# Altium schematic sheet main.SchDoc(main)
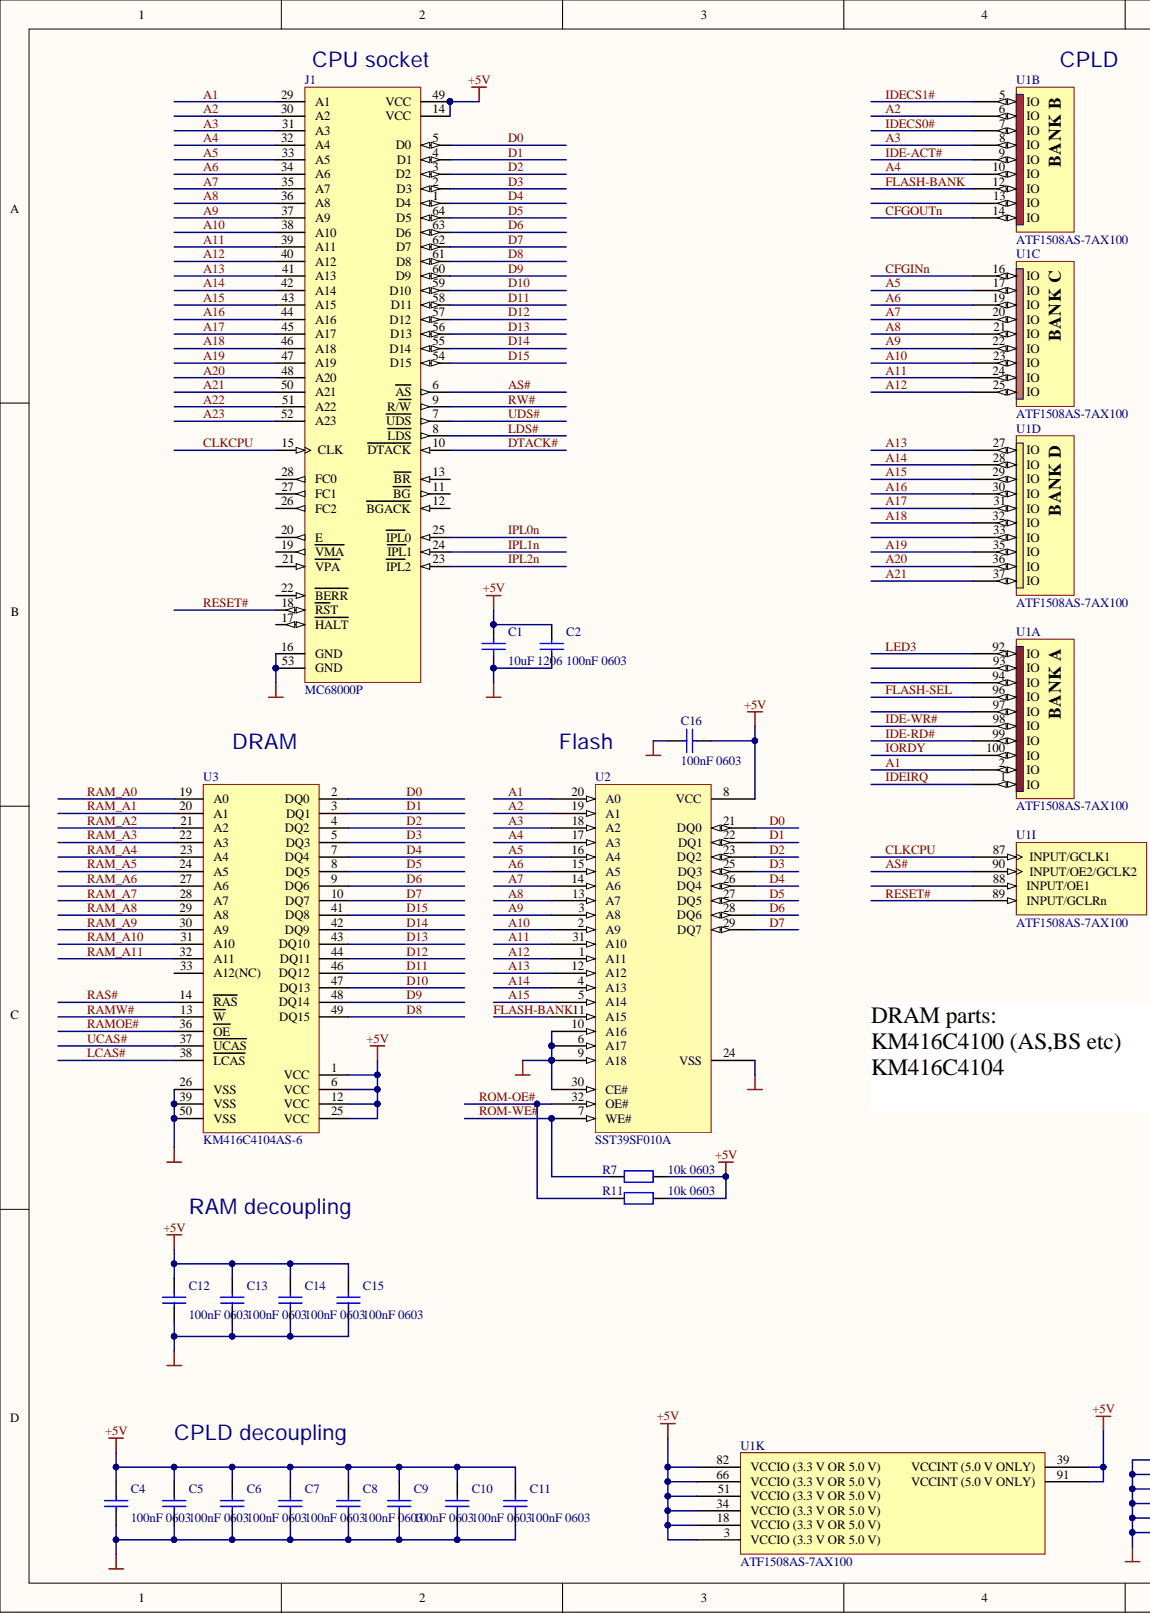
[diagram p0: main.SchDoc, left]
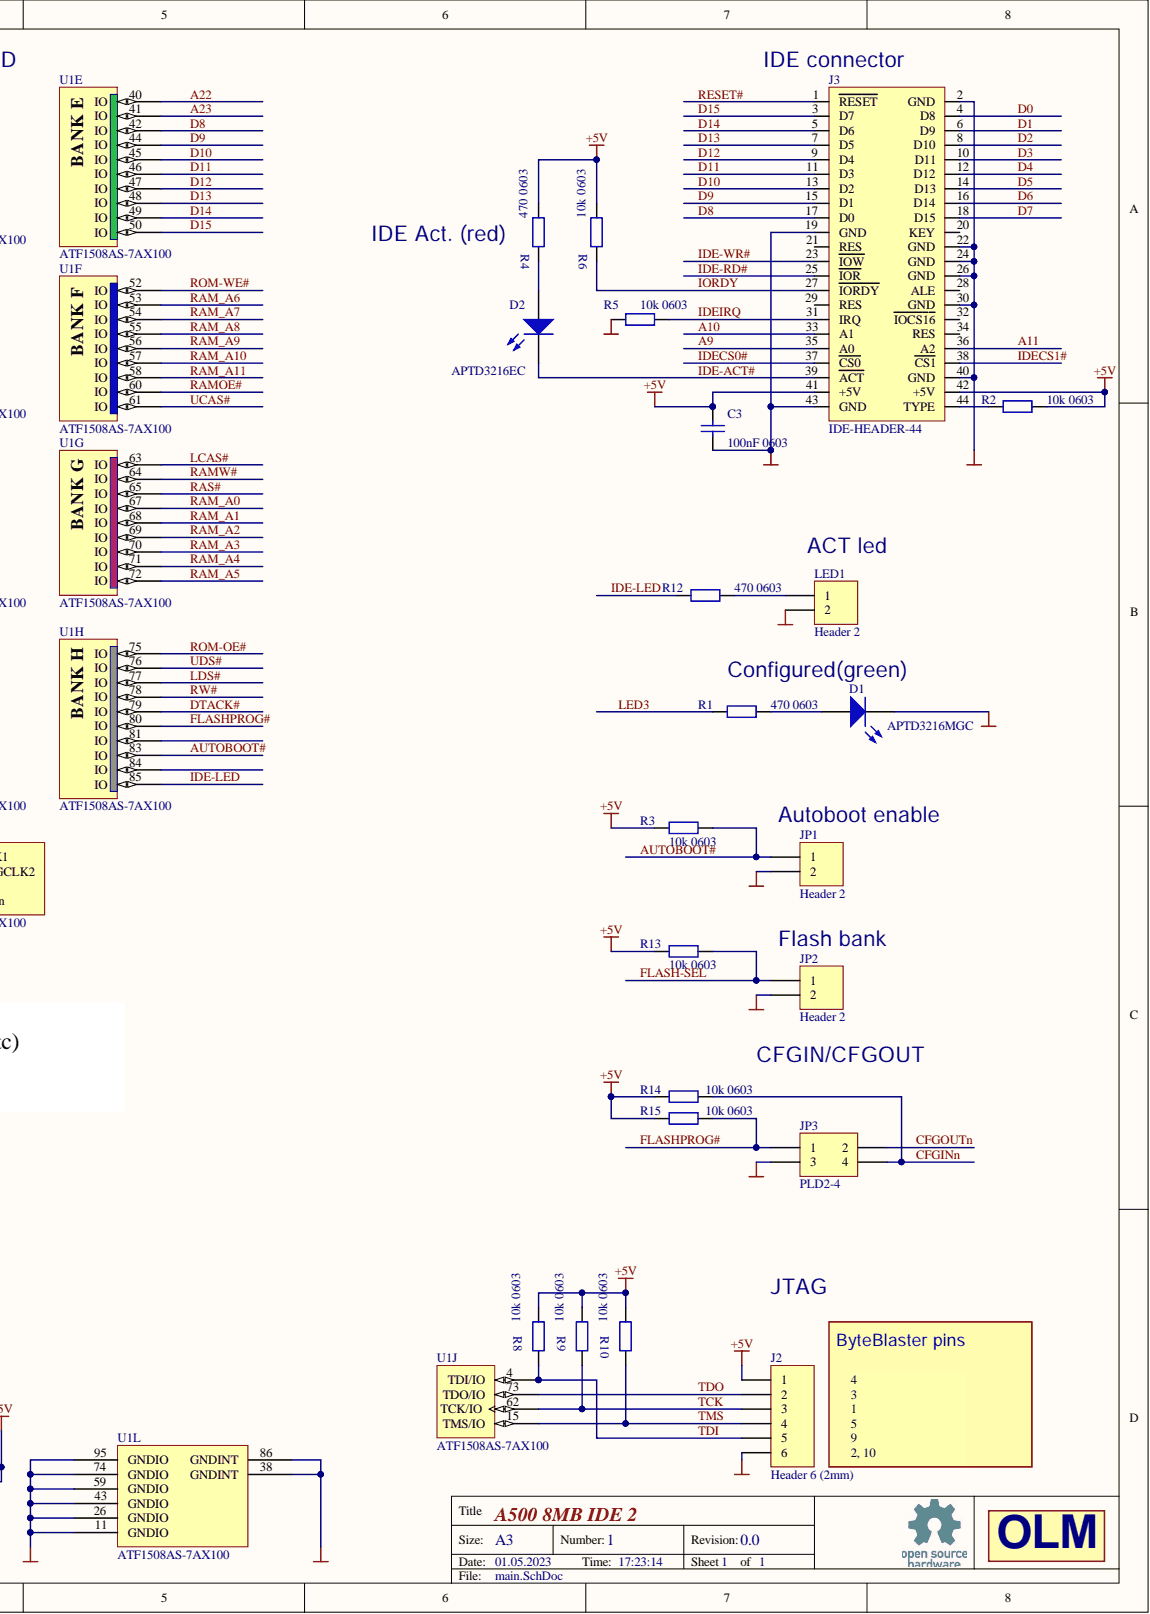
[diagram p1: main.SchDoc, right]

## Parts
A1  OSHW  [OSHW-LOGO-10MM]  (value not drawn)
C1  value 10uF 1206  [SM/C_1206]  (hidden comment: Capacitor)
C2  value 100nF 0603  [SM/C_0603]  (hidden comment: Capacitor)
C3  value 100nF 0603  [SM/C_0603]  (hidden comment: Cap)
C4  Cap  [SM/C_0603]  (value not drawn)
C5  value 100nF 0603  [SM/C_0603]  (hidden comment: Cap)
C6  value 100nF 0603  [SM/C_0603]  (hidden comment: Cap)
C7  value 100nF 0603  [SM/C_0603]  (hidden comment: Cap)
C8  value 100nF 0603  [SM/C_0603]  (hidden comment: Cap)
C9  value 100nF 0603  [SM/C_0603]  (hidden comment: Cap)
C10  value 100nF 0603  [SM/C_0603]  (hidden comment: Cap)
C11  value 100nF 0603  [SM/C_0603]  (hidden comment: Cap)
C12  Cap  [SM/C_0603]  (value not drawn)
C13  value 100nF 0603  [SM/C_0603]  (hidden comment: Cap)
C14  value 100nF 0603  [SM/C_0603]  (hidden comment: Cap)
C15  value 100nF 0603  [SM/C_0603]  (hidden comment: Cap)
C16  value 100nF 0603  [SM/C_0603]  (hidden comment: Cap)
D1  value APTD3216MGC  [SM/LED_0805_21_BLUE]  (hidden comment: LED)
D2  value APTD3216EC  [SM/LED_0805_21_BLUE]  (hidden comment: LED)
J1  MC68000P  [DIP.100/64/W.900-SKT]
J2  Header 6 (2mm)  [PLS2-6-RA-SMD]
J3  IDE-HEADER-44  [PLD2-44]
JP1  Header 2  [PLS-2-2MM]
JP2  Header 2  [PLS-2-2MM]
JP3  value PLD2-4  [PLD2-4]  (hidden comment: Header 2X2)
LED1  Header 2  [PLS-2-2MM]
R1  value 470 0603  [SM/R_0603]  (hidden comment: Резистор)
R2  value 10k 0603  [SM/R_0603]  (hidden comment: Резистор)
R3  value 10k 0603  [SM/R_0603]  (hidden comment: Resistor)
R4  value 470 0603  [SM/R_0603]  (hidden comment: Resistor)
R5  value 10k 0603  [SM/R_0603]  (hidden comment: Resistor)
R6  value 10k 0603  [SM/R_0603]  (hidden comment: Resistor)
R7  value 10k 0603  [SM/R_0603]  (hidden comment: Резистор)
R8  value 10k 0603  [SM/R_0603]  (hidden comment: Resistor)
R9  value 10k 0603  [SM/R_0603]  (hidden comment: Resistor)
R10  value 10k 0603  [SM/R_0603]  (hidden comment: Resistor)
R11  value 10k 0603  [SM/R_0603]  (hidden comment: Резистор)
R12  value 470 0603  [SM/R_0603]  (hidden comment: Резистор)
R13  value 10k 0603  [SM/R_0603]  (hidden comment: Resistor)
R14  value 10k 0603  [SM/R_0603]  (hidden comment: Resistor)
R15  value 10k 0603  [SM/R_0603]  (hidden comment: Resistor)
U1  ATF1508AS-7AX100  [TQFP100_16mm]  (parts A,B,C,D,E,F,G,H,I,J,K,L)
U2  value SST39SF010A  [TSOP32_WH_SST_MCH]  (hidden comment: SST39SF040A)
U3  value KM416C4104AS-6  [TSOP-II-50]  (hidden comment: 4Mx16 DRAM TSOP50)

## Nets
+5V: C1.2 C2.2 C3.2 C4.2 C5.2 C6.2 C7.2 C8.2 C9.2 C10.2 C11.2 C12.2 C13.2 C14.2 C15.2 C16.1 J1.14(VCC) J1.49(VCC) J2.1 J3.41(+5V) J3.42(+5V) R2.2 R3.2 R4.2 R6.2 R7.2 R8.2 R9.2 R10.2 R11.2 R13.2 R14.2 R15.2 U1.3(VCCIO_(3.3_V_OR_5.0_V)) U1.18(VCCIO_(3.3_V_OR_5.0_V)) U1.34(VCCIO_(3.3_V_OR_5.0_V)) U1.39(VCCINT_(5.0_V_ONLY)) U1.51(VCCIO_(3.3_V_OR_5.0_V)) U1.66(VCCIO_(3.3_V_OR_5.0_V)) U1.82(VCCIO_(3.3_V_OR_5.0_V)) U1.91(VCCINT_(5.0_V_ONLY)) U2.8(VCC) U3.1(VCC) U3.6(VCC) U3.12(VCC) U3.25(VCC)
GND: C1.1 C2.1 C3.1 C4.1 C5.1 C6.1 C7.1 C8.1 C9.1 C10.1 C11.1 C12.1 C13.1 C14.1 C15.1 C16.2 D1.2 J1.16(GND) J1.53(GND) J2.6 J3.2(GND) J3.19(GND) J3.22(GND) J3.24(GND) J3.26(GND) J3.30(GND) J3.40(GND) J3.43(GND) JP1.2 JP2.2 JP3.3 LED1.2 R5.1 U1.11(GNDIO) U1.26(GNDIO) U1.38(GNDINT) U1.43(GNDIO) U1.59(GNDIO) U1.74(GNDIO) U1.86(GNDINT) U1.95(GNDIO) U2.6(A17) U2.9(A18) U2.10(A16) U2.24(VSS) U2.30(CE#) U3.26(VSS) U3.39(VSS) U3.50(VSS)
A1: J1.29(A1) U1.2(IO) U2.20(A0)
A2: J1.30(A2) U1.6(IO) U2.19(A1)
A3: J1.31(A3) U1.8(IO) U2.18(A2)
A4: J1.32(A4) U1.10(IO) U2.17(A3)
A5: J1.33(A5) U1.17(IO) U2.16(A4)
A6: J1.34(A6) U1.19(IO) U2.15(A5)
A7: J1.35(A7) U1.20(IO) U2.14(A6)
A8: J1.36(A8) U1.21(IO) U2.13(A7)
A9: J1.37(A9) J3.35(A0) U1.22(IO) U2.3(A8)
A10: J1.38(A10) J3.33(A1) U1.23(IO) U2.2(A9)
A11: J1.39(A11) J3.36(A2) U1.24(IO) U2.31(A10)
A12: J1.40(A12) U1.25(IO) U2.1(A11)
A13: J1.41(A13) U1.27(IO) U2.12(A12)
A14: J1.42(A14) U1.28(IO) U2.4(A13)
A15: J1.43(A15) U1.29(IO) U2.5(A14)
A16: J1.44(A16) U1.30(IO)
A17: J1.45(A17) U1.31(IO)
A18: J1.46(A18) U1.32(IO)
A19: J1.47(A19) U1.35(IO)
A20: J1.48(A20) U1.36(IO)
A21: J1.50(A21) U1.37(IO)
A22: J1.51(A22) U1.40(IO)
A23: J1.52(A23) U1.41(IO)
AS#: J1.6(AS) U1.90(INPUT/OE2/GCLK2)
AUTOBOOT#: JP1.1 R3.1 U1.83(IO)
CFGINN: JP3.4 R14.1 U1.16(IO)
CFGOUTN: JP3.2 U1.14(IO)
CLKCPU: J1.15(CLK) U1.87(INPUT/GCLK1)
D0: J1.5(D0) J3.4(D8) U2.21(DQ0) U3.2(DQ0)
D1: J1.4(D1) J3.6(D9) U2.22(DQ1) U3.3(DQ1)
D2: J1.3(D2) J3.8(D10) U2.23(DQ2) U3.4(DQ2)
D3: J1.2(D3) J3.10(D11) U2.25(DQ3) U3.5(DQ3)
D4: J1.1(D4) J3.12(D12) U2.26(DQ4) U3.7(DQ4)
D5: J1.64(D5) J3.14(D13) U2.27(DQ5) U3.8(DQ5)
D6: J1.63(D6) J3.16(D14) U2.28(DQ6) U3.9(DQ6)
D7: J1.62(D7) J3.18(D15) U2.29(DQ7) U3.10(DQ7)
D8: J1.61(D8) J3.17(D0) U1.42(IO) U3.49(DQ15)
D9: J1.60(D9) J3.15(D1) U1.44(IO) U3.48(DQ14)
D10: J1.59(D10) J3.13(D2) U1.45(IO) U3.47(DQ13)
D11: J1.58(D11) J3.11(D3) U1.46(IO) U3.46(DQ12)
D12: J1.57(D12) J3.9(D4) U1.47(IO) U3.44(DQ11)
D13: J1.56(D13) J3.7(D5) U1.48(IO) U3.43(DQ10)
D14: J1.55(D14) J3.5(D6) U1.49(IO) U3.42(DQ9)
D15: J1.54(D15) J3.3(D7) U1.50(IO) U3.41(DQ8)
DTACK#: J1.10(DTACK) U1.79(IO)
FLASH-BANK: U1.12(IO) U2.11(A15)
FLASH-SEL: JP2.1 R13.1 U1.96(IO)
FLASHPROG#: JP3.1 R15.1 U1.80(IO)
IDE-ACT#: D2.2 J3.39(ACT) U1.9(IO)
IDE-LED: R12.2 U1.85(IO)
IDE-RD#: J3.25(IOR) U1.99(IO)
IDE-WR#: J3.23(IOW) U1.98(IO)
IDECS0#: J3.37(CS0) U1.7(IO)
IDECS1#: J3.38(CS1) U1.5(IO)
IDEIRQ: J3.31(IRQ) R5.2 U1.1(IO)
IORDY: J3.27(IORDY) R6.1 U1.100(IO)
IPL0N: J1.25(IPL0)
IPL1N: J1.24(IPL1)
IPL2N: J1.23(IPL2)
LCAS#: U1.63(IO) U3.38(LCAS)
LDS#: J1.8(LDS) U1.77(IO)
LED3: R1.2 U1.92(IO)
RAM_A0: U1.67(IO) U3.19(A0)
RAM_A1: U1.68(IO) U3.20(A1)
RAM_A2: U1.69(IO) U3.21(A2)
RAM_A3: U1.70(IO) U3.22(A3)
RAM_A4: U1.71(IO) U3.23(A4)
RAM_A5: U1.72(IO) U3.24(A5)
RAM_A6: U1.53(IO) U3.27(A6)
RAM_A7: U1.54(IO) U3.28(A7)
RAM_A8: U1.55(IO) U3.29(A8)
RAM_A9: U1.56(IO) U3.30(A9)
RAM_A10: U1.57(IO) U3.31(A10)
RAM_A11: U1.58(IO) U3.32(A11)
RAMOE#: U1.60(IO) U3.36(OE)
RAMW#: U1.64(IO) U3.13(W)
RAS#: U1.65(IO) U3.14(RAS)
RESET#: J1.18(RST) J3.1(RESET) U1.89(INPUT/GCLRn)
ROM-OE#: R11.1 U1.75(IO) U2.32(OE#)
ROM-WE#: R7.1 U1.52(IO) U2.7(WE#)
RW#: J1.9(R/W) U1.78(IO)
TCK: J2.3 R9.1 U1.62(TCK/IO)
TDI: J2.5 R8.1 U1.4(TDI/IO)
TDO: J2.2 U1.73(TDO/IO)
TMS: J2.4 R10.1 U1.15(TMS/IO)
UCAS#: U1.61(IO) U3.37(UCAS)
UDS#: J1.7(UDS) U1.76(IO)
NetD1_1: D1.1 R1.1
NetD2_1: D2.1 R4.1
NetJ3_44: J3.44(TYPE) R2.1
NetLED1_1: LED1.1 R12.1

Not connected: J1 11(BG) 12(BGACK) 13(BR) 17(HALT) 19(VMA) 20(E) 21(VPA) 22(BERR) 26(FC2) 27(FC1) 28(FC0); J3 20(KEY) 21(RES) 28(ALE) 29(RES) 32(IOCS16) 34(RES); U1 13(IO) 33(IO) 81(IO) 84(IO) 88(INPUT/OE1) 93(IO) 94(IO) 97(IO); U3 33(A12(NC))

## Library data of the parts
C1 = Cap ceramic: Ceramic capacitor SMD; Manufacturer: Kemet; Part: C1206C106K4RACTU; Value: 10uF 1206; Component Kind: Standard; Library Name: New.SCHLIB
C2 = Cap ceramic: Ceramic capacitor SMD; Manufacturer: Samsung; Part: CL10B104KB8NNNL; Component Kind: Standard; Library Name: New.SCHLIB
C3 C5-C11 C13-C16 = Cap ceramic: Ceramic capacitor SMD; Component Kind: Standard; Library Name: New.SCHLIB
C4 C12 = Cap ceramic: Ceramic capacitor SMD; Value: 100nF 0603; Component Kind: Standard; Library Name: New.SCHLIB
D1 = LED0: LED Green; Примечание: Kingbright; Component Kind: Standard; LatestRevisionDate: 08-Jul-2005; LatestRevisionNote: Mech Layer 1 removed.; Library Name: Miscellaneous Devices.IntLib; PackageDescription: LED; 2 Leads; PackageReference: LED-0; Published: 8-Jun-2000; Publisher: Altium Limited
D2 = LED0: LED Red; Примечание: Kingbright; Component Kind: Standard; LatestRevisionDate: 08-Jul-2005; LatestRevisionNote: Mech Layer 1 removed.; Library Name: Miscellaneous Devices.IntLib; PackageDescription: LED; 2 Leads; PackageReference: LED-0; Published: 8-Jun-2000; Publisher: Altium Limited
J1 = MC68000P: 64 combined socket/pins; Value: Socket 1-pin x 64; Component Kind: Standard; DatasheetVersion: 1990; LatestRevisionDate: 06-Jun-2005; LatestRevisionNote: Stylized 3D Model Added.; PackageDescription: DIP; 64 Leads; Row Spacing 22.86 mm; Pitch 2.54 mm; PackageReference: 754-01; PCB3D: 746-01; Published: 17-Jul-2002; Library Name: Motorola Microprocessor 16-Bit.IntLib; Publisher: Altium Limited
J2 = Header 6: Header, 6-Pin, 2mm pitch; Value: PLS2-6; Component Kind: Standard; LatestRevisionDate: 17-Jul-2002; LatestRevisionNote: Re-released for DXP Platform.; Library Name: Miscellaneous Connectors.IntLib; Signal Integrity: Connector; Publisher: Altium Limited
JP1 JP2 LED1 = Header 2: Header, 2-Pin, 2.54mm pitch; Value: PLS-2; Component Kind: Standard; LatestRevisionDate: 17-Jul-2002; LatestRevisionNote: Re-released for DXP Platform.; Library Name: Miscellaneous Connectors.IntLib; Signal Integrity: Connector; Publisher: Altium Limited
JP3 = Header 2X2: Header, 2-Pin, Dual row; Component Kind: Standard; LatestRevisionDate: 17-Jul-2002; LatestRevisionNote: Re-released for DXP Platform.; Library Name: Miscellaneous Connectors.IntLib; Publisher: Altium Limited
R1 R12 = Res2: Resistor; Value: 470 0603; Component Kind: Standard; LatestRevisionDate: 17-Jul-2002; LatestRevisionNote: Re-released for DXP Platform.; Library Name: Miscellaneous Devices.IntLib; PackageReference: AXIAL-0.4; Published: 8-Jun-2000; Signal Integrity: Res; Simulation: RESISTOR; Publisher: Altium Limited
R2 R7 R11 = Res2: Resistor; Component Kind: Standard; LatestRevisionDate: 17-Jul-2002; LatestRevisionNote: Re-released for DXP Platform.; Library Name: Miscellaneous Devices.IntLib; PackageReference: AXIAL-0.4; Published: 8-Jun-2000; Signal Integrity: Res; Simulation: RESISTOR; Publisher: Altium Limited
R3-R6 R8-R10 R13-R15 = Res2: Part: 0R 5% 0603; Component Kind: Standard; Description: Resistor; LatestRevisionDate: 17-Jul-2002; LatestRevisionNote: Re-released for DXP Platform.; Library Name: Miscellaneous Devices.IntLib; PackageReference: AXIAL-0.4; Published: 8-Jun-2000; Signal Integrity: Res; Simulation: RESISTOR; Publisher: Altium Limited
U1 = EPM7128STC100-7: 128 macrocells CPLD; Component Kind: Standard; ComponentLink2Description: Datasheet; ComponentLink3Description: Packaging Specification; ComponentLink3URL: http://www.altera.com/literature/ds/dspkg.pdf; Library Name: Altera MAX 7000S.IntLib; PackageReference: TQFP100; PCB3D: EPM7128S-TQFP100; Signal Integrity: 7k; Supplier 1: Digi-Key; Supplier Part Number 1: 544-1785-ND; Value: ATF1508AS-7AX100
U2 = SST39SF040A: 4Mb FLASH MEMORY; Manufacturer: Micron Technology Inc; CategoryI: Integrated Circuits (ICs); CategoryII: NOR FLASH MEMORY; DesignedBy: Circuitry Parts; SchematicRev: A; CircuitryPartsPN: SST39SF040A; Component Kind: Standard; DesignedOn: 7/18/2005 3:14:54 PM; Library Name: NOR_FLASH.schlib; ManufacturerPN: SST39SF040A; MfgURL: http://www.micron.com/; PackageName: TSOP32
U3 = 4Mx16 DRAM: 4Mx16 EDO DRAM TSOP-II-50; Component Kind: Standard; Library Name: Memory.SchLib
A1 = OSHW: Component Kind: Standard; Description: OSHW; Library Name: MECH_Library_home.SchLib
J3 = IDE-HEADER-44: Component Kind: Standard; Description: IDE-HEADER-44; Library Name: Connectors.SchLib
